# Altium schematic sheet USBINFRTransiever_POWER.SchDoc
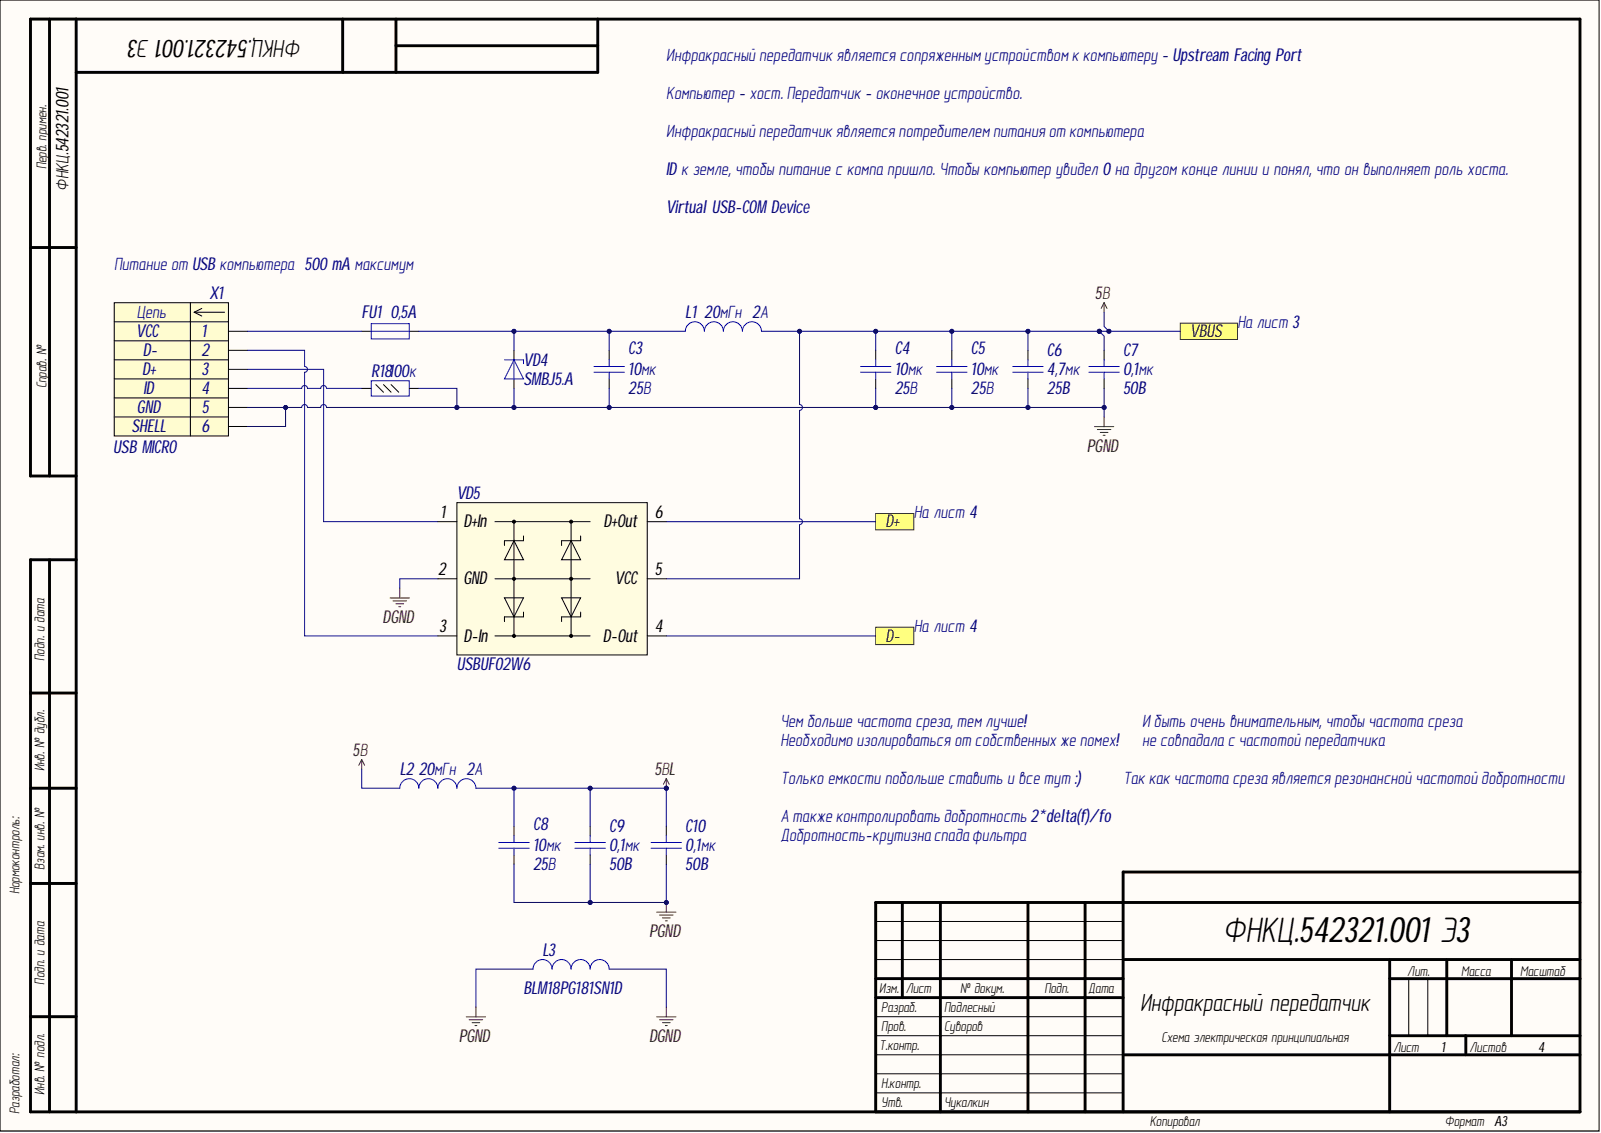
[diagram p0: USBINFRTransiever_POWER.SchDoc]

## Parts
C3  value 10мк  Voltage: 25В  [C1206]  (hidden comment: Capacitor)
C4  value 10мк  Voltage: 25В  [C1206]  (hidden comment: Capacitor)
C5  value 10мк  Voltage: 25В  [C1206]  (hidden comment: Capacitor)
C6  value 4,7мк  Voltage: 25B  [C0805]  (hidden comment: Capacitor)
C7  value 0,1мк  Voltage: 50B  [C0805]  (hidden comment: Capacitor)
C8  value 10мк  Voltage: 25В  [C1206]  (hidden comment: Capacitor)
C9  value 0,1мк  Voltage: 50B  [C0805]  (hidden comment: Capacitor)
C10  value 0,1мк  Voltage: 50B  [C0805]  (hidden comment: Capacitor)
FU1  I hold: 0,5A  [FUSE812]  (hidden comment: Fuse)
L1  value 20мГн  Rated Current: 2А  [L0805]  (hidden comment: Inductor)
L2  value 20мГн  Rated Current: 2А  [L0805]  (hidden comment: Inductor)
L3  Part Number: BLM18PG181SN1D  [L0603]  (hidden comment: Inductor)
R18  value 100к  [R0603]  (hidden comment: Resistor_0,062Вт)
VD4  DiodeZener  [SMB]  (value not drawn)
VD5  USBUF  Part Number: USBUF02W6  [SOT323-6L]
X1  USB MICRO  Contact_1: VCC  Contact_2: D-  Contact_3: D+  Contact_4: ID  Contact_5: GND  [47590-0001]

## Ports
D+, D-, VBUS

## Nets
5ВL: C8.2 C9.2 C10.2 L2.2
DGND: L3.2 VD5.2(GND)
PGND: C3.1 C4.1 C5.1 C6.1 C7.1 C8.1 C9.1 C10.1 L3.1 R18.2 VD4.2 X1.5 X1.6
5В: C4.2 C5.2 C6.2 C7.2 L1.2 L2.1 VD5.5(VCC) ; port VBUS
NetC3_2: C3.2 FU1.2 L1.1 VD4.1
NetFU1_1: FU1.1 X1.1
NetR18_1: R18.1 X1.4
NetVD5_1: VD5.1(D+In) X1.3
NetVD5_3: VD5.3(D-In) X1.2
NetVD5_4: VD5.4(D-Out)
NetVD5_6: VD5.6(D+Out)

## Library data of the parts
C3-C5 C8 = cap187: Конденсатор; Manufacture: Murata; Part Name: Ceramic; Part Number: GRM31CR71E106KA12L*; Database Table Name: Capacitors$; TKE: X7R; Component Kind: Standard; id: cap187; Library Name: MOP44.DbLib
C6 = cap154: Конденсатор; Manufacture: Murata; Part Name: Ceramic; Part Number: GRM21BR61E475KA12L; Database Table Name: Capacitors$; TKE: X5R; Component Kind: Standard; id: cap154; Library Name: MOP44.DbLib
C7 C9 C10 = cap142: Конденсатор; Manufacture: Murata; Part Name: Ceramic; Part Number: GRM21BR71H104K**; Database Table Name: Capacitors$; TKE: X7R; Component Kind: Standard; id: cap142; Library Name: MOP44.DbLib
FU1 = fuse8: Предохранитель; Manufacture: Bourns; Part Name: Resettable Fuses; Part Number: MF-MSMF050; Database Table Name: Fuse$; I max: 100A; I trip: 1A; Resistance: 0,15Ohms; V max: 15B; Component Kind: Standard; id: fuse8; Library Name: MOP44.DbLib
L1 L2 = ind69: EMI Suppression Filter; Manufacture: Murata; Part Name: EMI Inductor; Part Number: BLM21PG221SN1D; Database Table Name: Inductor$; Resistance: 0,05Ohm; Component Kind: Standard; id: ind69; Library Name: MOP44.DbLib
L3 = ind0: EMI Suppression Filter; Part Name: EMI Suppression Filters; Database Table Name: Inductor$; Rated Current: 1,5A; Resistance: 0,09Ohm; Component Kind: Standard; id: ind0; Library Name: MOP44.DbLib
R18 = res507: Резистор; Manufacture: Yageo; Part Number: RC0603FR-07100KL; Accuracy: 1%; Database Table Name: Resistor$; Component Kind: Standard; id: res507; Library Name: MOP44.DbLib; Part Name: Resistor; Power: 0,062Вт
VD4 = SMBJ5.A: Cтабилитрон (лавинный выпрямительный диод) односторонний; Manufacture: ST Microelectronics; Part Name: TVS diode Unidirectional; Breakdown Voltage: 6,74B; Clamping voltage: 9,2B; Database Table Name: TVSdiode$; Dynamic resistance: 0,031Ohm; id: tvs68; Leakage Current: 20uA; Number of diodes: 1; Peak Pulse Power: 600W; Stand-off Voltage: 5B; Component Kind: Standard; Library Name: MOP44.DbLib; Part Number: SMBJ5.A
VD5 = diode14: EMEMI filter and line termination for USB upstream ports; Manufacture: ST Microelectronics; Part Name: EMI filter for USB upstream ports; Capacitance: 47pF; Database Table Name: Diode$; Number of Channels: 2; Component Kind: Standard; id: diode14; Library Name: MOP44.DbLib
X1 = connect47: Manufacture1: Molex; Part Name: Micro-USB AB Receptacle; Contact_6: Shell; Database Table Name: Connector$; Component Kind: Standard; id: connect47; Library Name: MOP44.DbLib
